# Altium schematic sheet Sheet3.SchDoc(Sheet3)
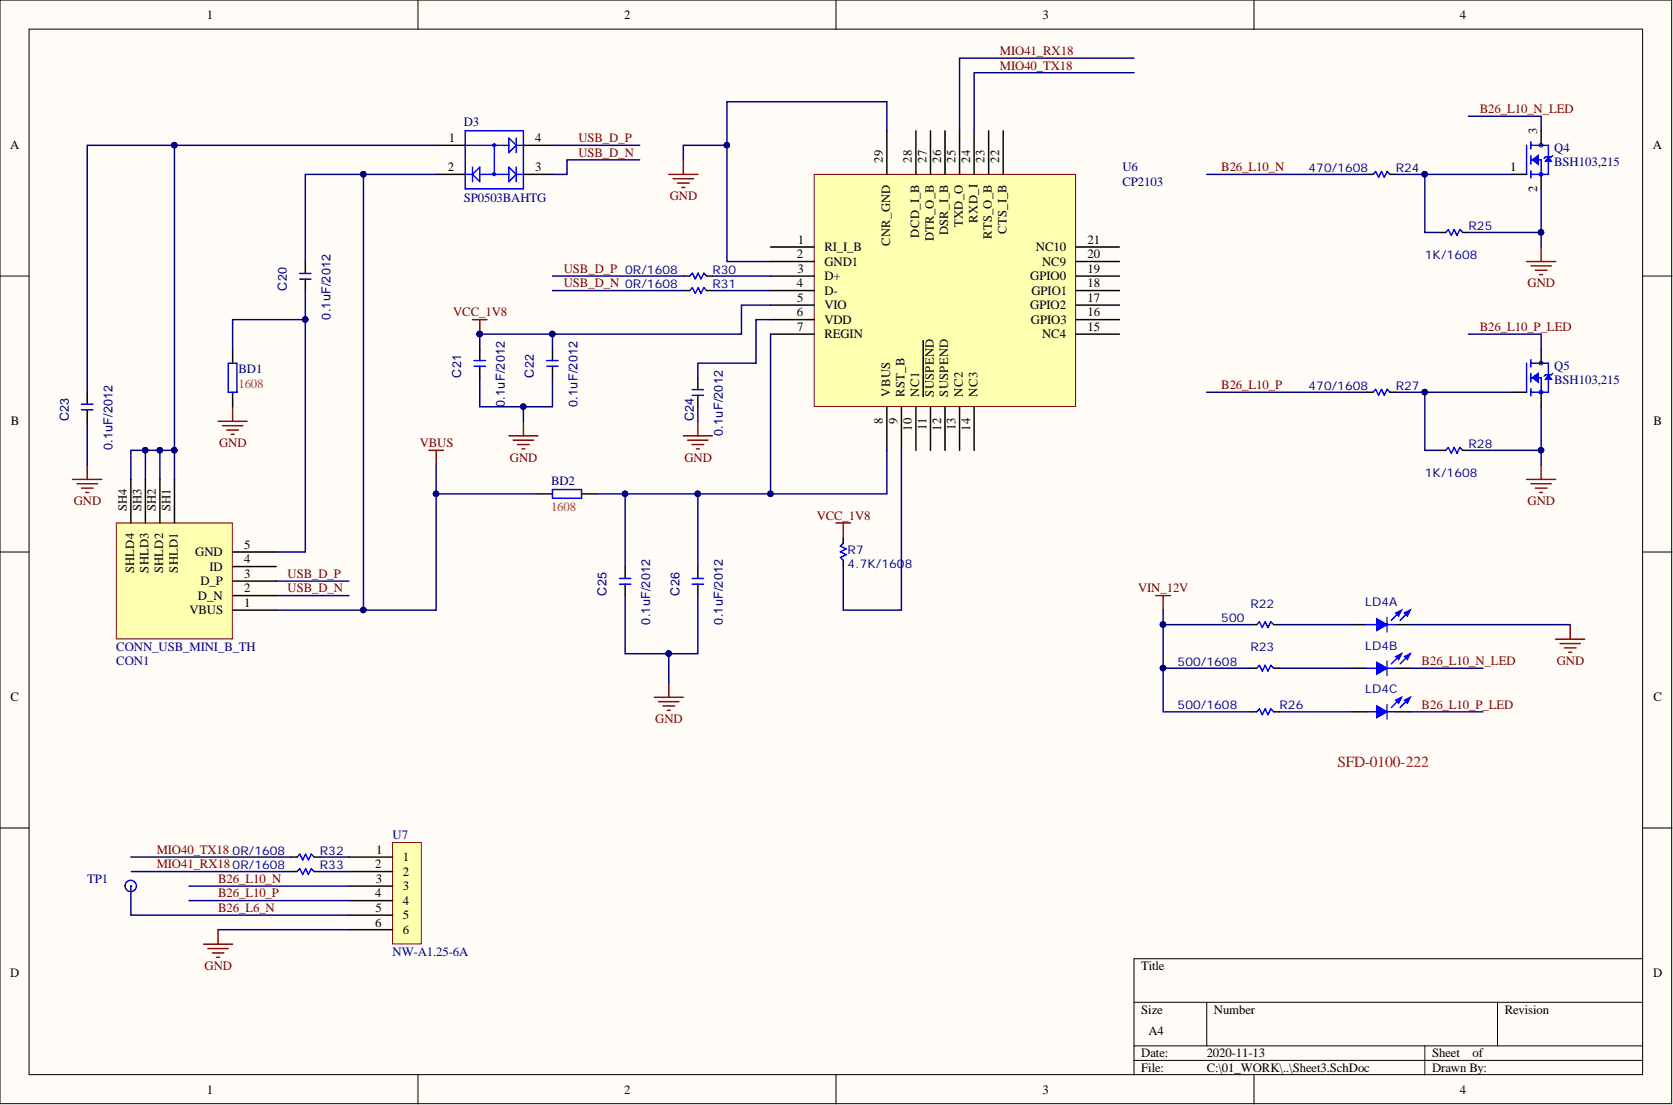
[diagram p0: Sheet3.SchDoc]

## Parts
BD1  Package: 1608  [L1608]
BD2  Package: 1608  [L1608]
C20  0.1uF/2012  [C2012]
C21  0.1uF/2012  [C2012]
C22  0.1uF/2012  [C2012]
C23  0.1uF/2012  [C2012]
C24  0.1uF/2012  [C2012]
C25  0.1uF/2012  [C2012]
C26  0.1uF/2012  [C2012]
CON1  CONN_USB_MINI_B_TH  [USB MINI 5P DIPE B TYPE_XM7D-0512]
D3  SP0503BAHTG  [SOT143 4PIN]
LD4  (no value)  [SFD-0100-222]  (parts A,B,C)
Q4  BSH103,215  [SOT23]
Q5  BSH103,215  [SOT23]
R7  4.7K/1608  [R1608]
R22  500  [R1608]
R23  500/1608  [R1608]
R24  470/1608  [R1608]
R25  1K/1608  [R1608]
R26  500/1608  [R1608]
R27  470/1608  [R1608]
R28  1K/1608  [R1608]
R30  0R/1608  [R1608]
R31  0R/1608  [R1608]
R32  0R/1608  [R1608]
R33  0R/1608  [R1608]
TP1  TP  [TP_0.9]
U6  CP2103  [QFN50P500X500X90_HS-29N]
U7  NW-A1.25-6A  [NW-A1.25-6A]

## Nets
GND: BD1.1 C21.1 C22.1 C23.1 C24.1 C25.1 C26.1 LD4.1 Q4.2 Q5.2 R25.2 R28.2 U6.2(GND1) U6.29(CNR_GND) U7.6
VBUS: BD2.1 C20.2 CON1.1(VBUS) D3.2
VCC_1V8: C21.2 C22.2 R7.2 U6.5(VIO)
VIN_12V: R22.1 R23.1 R26.1
B26_L6_N: TP1.1 U7.5
B26_L10_N: R24.1 U7.3
B26_L10_N_LED: LD4.3 Q4.3
B26_L10_P: R27.1 U7.4
B26_L10_P_LED: LD4.5 Q5.3
MIO40_TX18: R32.1 U6.24(RXD_I)
MIO41_RX18: R33.1 U6.25(TXD_O)
USB_D_N: CON1.2(D_N) D3.3 R31.1
USB_D_P: CON1.3(D_P) D3.4 R30.1
NetBD1_2: BD1.2 C20.1 CON1.5(GND)
NetBD2_2: BD2.2 C25.2 C26.2 U6.7(REGIN) U6.8(VBUS)
NetC23_2: C23.2 CON1.SH1(SHLD1) CON1.SH2(SHLD2) CON1.SH3(SHLD3) CON1.SH4(SHLD4) D3.1
NetC24_2: C24.2 U6.6(VDD)
NetLD4_2: LD4.2 R22.2
NetLD4_4: LD4.4 R23.2
NetLD4_6: LD4.6 R26.2
NetQ4_1: Q4.1 R24.2 R25.1
NetQ5_1: Q5.1 R27.2 R28.1
NetR7_1: R7.1 U6.9(RST_B)
NetR30_2: R30.2 U6.3(D+)
NetR31_2: R31.2 U6.4(D-)
NetR32_2: R32.2 U7.1
NetR33_2: R33.2 U7.2

Not connected: CON1 4(ID); U6 1(RI_I_B) 10(NC1) 11(SUSPEND) 12(SUSPEND) 13(NC2) 14(NC3) 15(NC4) 16(GPIO3) 17(GPIO2) 18(GPIO1) 19(GPIO0) 20(NC9) 21(NC10) 22(CTS_I_B) 23(RTS_O_B) 26(DSR_I_B) 27(DTR_O_B) 28(DCD_I_B)

## Library data of the parts
BD1 BD2 = BD1608: BEAD; Component Kind: Standard; Library Name: zerom_mm.SchLib
C20-C26 = C2012: Chip Capacitor Ceramic; Value: uF; Component Kind: Standard; Library Name: zerom_mm.SchLib
D3 = SP0503BAHTG: 8.5V Clamp Ipp Tvs Diode Surface Mount SOT-143-4; MF: Littelfuse Inc.; SnapEDA_Link: https://www.snapeda.com/parts/SP0503BAHTG/Littelfuse%20Inc./view-part/214427/?ref=snap; Package: SOT-143 Littelfuse; MP: SP0503BAHTG; Library Name: SnapEDAsch.SchLib; Digi-Key_Purchase_URL: https://www.digikey.com/product-detail/en/littelfuse-inc/SP0503BAHTG/F2715CT-ND/1154322?utm_source=snapeda&utm_medium=aggregator&utm_campaign=symbol; Digi-Key_Part_Number: F2715CT-ND; Component Kind: Standard
LD4 = SFD-0100-222: Chip LED Lamp; Component Kind: Standard; Library Name: Lumir_Power_Board.SCHLIB
Q4 Q5 = BSH103,215: N-Channel 30V 850mA _Ta_ 540mW _Ta_ Surface Mount TO-236AB; MF: Nexperia USA; SnapEDA_Link: https://www.snapeda.com/parts/BSH103,215/Nexperia%20USA%20Inc./view-part/624233/?ref=snap; Price: None; Package: SOT-23-3 Nexperia; MP: BSH103,215; Library Name: BSH103_215.IntLib; Component Kind: Standard; Availability: Unavailable
R7 R22-R28 R30-R33 = R1608: Chip Resistor; Value: OHM; Component Kind: Standard; Library Name: zerom_mm.SchLib
TP1 = TP: TEST POINT; Component Kind: Standard; Library Name: zerom.SchLib
U7 = NW-A1.25-6A: 6pin 1.25mm; Library Name: NW-A1.25-6A.SchLib; Component Kind: Standard
CON1 = CONN_USB_MINI_B_TH: Library Name: CONN_USB_MINI_B_TH.SchLib; Description: CONN_USB_MINI_B_TH; Component Kind: Standard
U6 = CP2103: Component Kind: Standard; Library Name: Lumir_Power_Board.SCHLIB
